# Altium schematic sheet jlink_ob.SchDoc
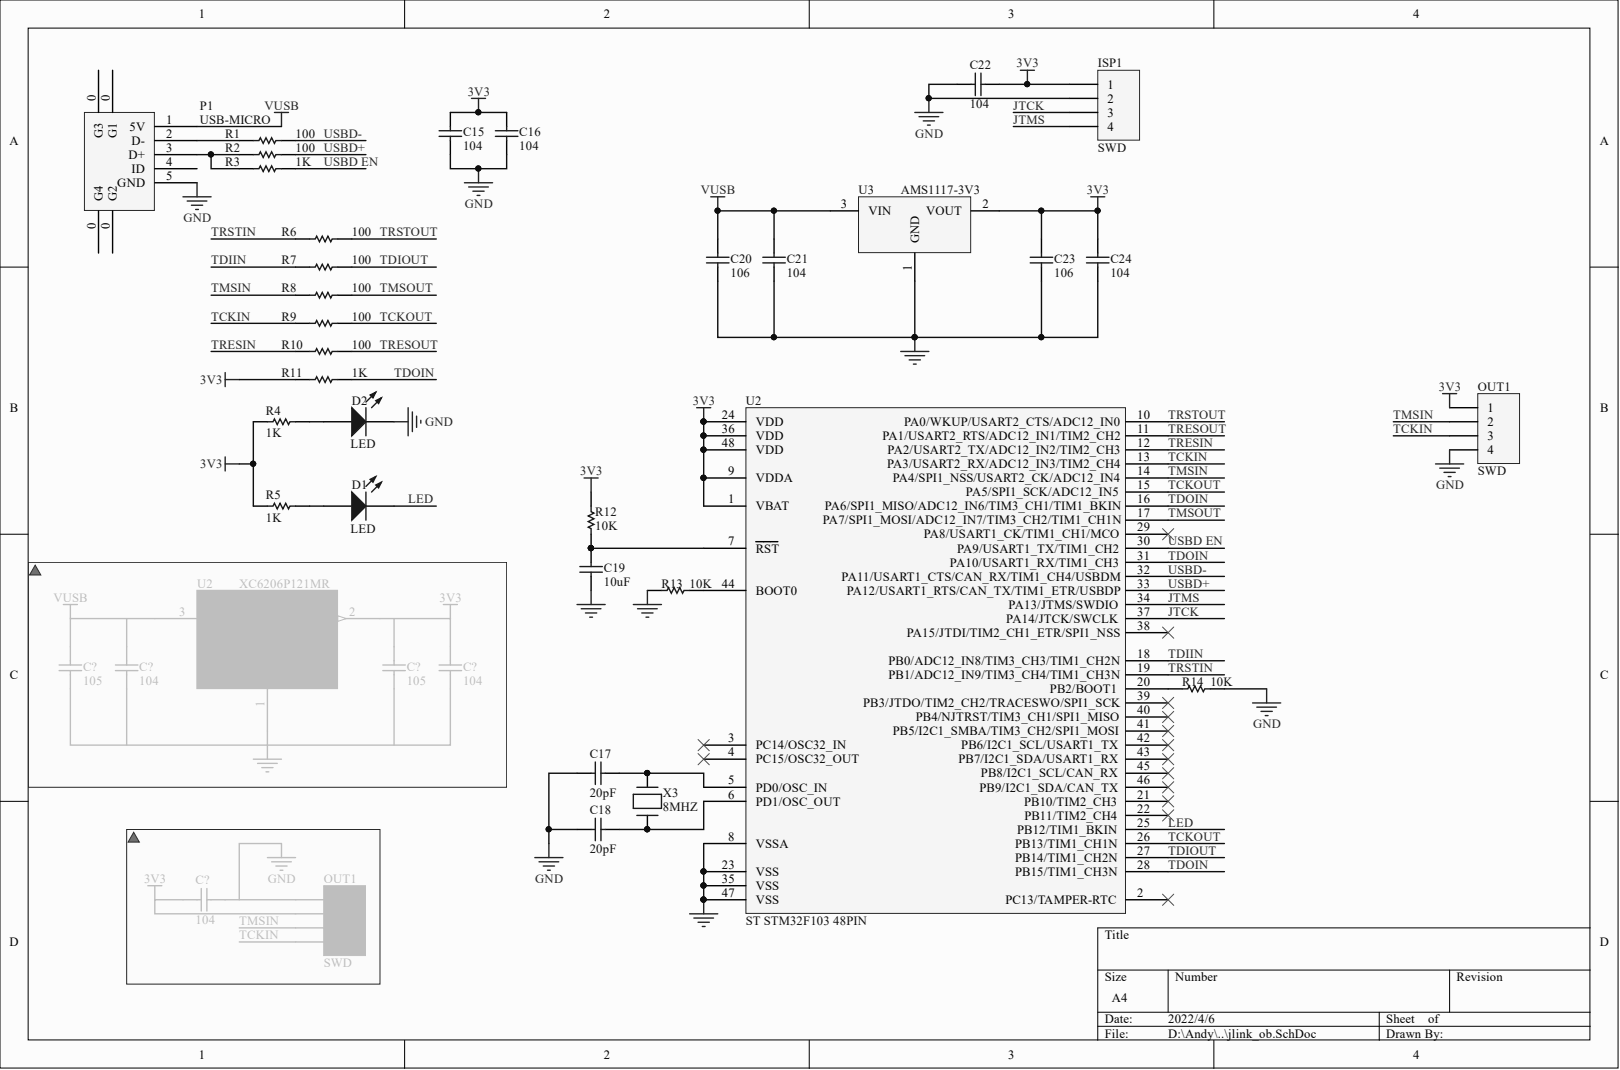
[diagram p0: jlink_ob.SchDoc]

## Parts
C15  value 104  [C0603]  (hidden comment: Cap Semi)
C16  value 104  [C0603]  (hidden comment: Cap Semi)
C17  value 20pF  [C0603]  (hidden comment: Cap Semi)
C18  value 20pF  [C0603]  (hidden comment: Cap Semi)
C19  value 10uF  [C0603]  (hidden comment: Cap Semi)
C20  value 106  [C0603]  (hidden comment: Cap Semi)
C21  value 104  [C0603]  (hidden comment: Cap Semi)
C22  value 104  [C0603]  (hidden comment: Cap Semi)
C23  value 106  [C0603]  (hidden comment: Cap Semi)
C24  value 104  [C0603]  (hidden comment: Cap Semi)
D1  LED  [0603]
D2  LED  [0603]
ISP1  SWD  [HDR1X4]
OUT1  SWD  [HDR1X4]
P1  USB-MICRO  [USB-MICRO]
R1  value 100  [R0603-M]  (hidden comment: Resistors SMD)
R2  value 100  [R0603-M]  (hidden comment: Resistors SMD)
R3  value 1K  [R0603-M]  (hidden comment: Resistors SMD)
R4  value 1K  [R0603-M]  (hidden comment: Resistors SMD)
R5  value 1K  [R0603-M]  (hidden comment: Resistors SMD)
R6  value 100  [R0603-M]  (hidden comment: Resistors SMD)
R7  value 100  [R0603-M]  (hidden comment: Resistors SMD)
R8  value 100  [R0603-M]  (hidden comment: Resistors SMD)
R9  value 100  [R0603-M]  (hidden comment: Resistors SMD)
R10  value 100  [R0603-M]  (hidden comment: Resistors SMD)
R11  value 1K  [R0603-M]  (hidden comment: Resistors SMD)
R12  value 10K  [R0603-M]  (hidden comment: Resistors SMD)
R13  value 10K  [R0603-M]  (hidden comment: Resistors SMD)
R14  value 10K  [R0603-M]  (hidden comment: Resistors SMD)
U2  ST STM32F103 48PIN  [ST LQFP-48 7x7MM]
U3  AMS1117-3V3  [SOT-223]
X3  8MHZ  [XTAL_ABLS2_ABR]

## Nets
3V3: C15.2 C16.2 C22.1 C23.2 C24.1 ISP1.1 OUT1.1 R4.2 R5.2 R11.2 R12.2 U2.1(VBAT) U2.9(VDDA) U2.24(VDD) U2.36(VDD) U2.48(VDD) U3.2
GND: C15.1 C16.1 C17.2 C18.2 C19.1 C20.1 C21.2 C22.2 C23.1 C24.2 D2.2 ISP1.2 OUT1.4 P1.5(GND) R13.1 R14.2 U2.8(VSSA) U2.23(VSS) U2.35(VSS) U2.47(VSS) U3.1
VUSB: C20.2 C21.1 P1.1(5V) U3.3
JTCK: ISP1.3 U2.37(PA14/JTCK/SWCLK)
JTMS: ISP1.4 U2.34(PA13/JTMS/SWDIO)
LED: D1.2 U2.25(PB12/TIM1_BKIN)
TCKIN: OUT1.3 R9.1 U2.13(PA3/USART2_RX/ADC12_IN3/TIM2_CH4)
TCKOUT: R9.2 U2.15(PA5/SPI1_SCK/ADC12_IN5) U2.26(PB13/TIM1_CH1N)
TDIIN: R7.1 U2.18(PB0/ADC12_IN8/TIM3_CH3/TIM1_CH2N)
TDIOUT: R7.2 U2.27(PB14/TIM1_CH2N)
TDOIN: R11.1 U2.16(PA6/SPI1_MISO/ADC12_IN6/TIM3_CH1/TIM1_BKIN) U2.28(PB15/TIM1_CH3N) U2.31(PA10/USART1_RX/TIM1_CH3)
TMSIN: OUT1.2 R8.1 U2.14(PA4/SPI1_NSS/USART2_CK/ADC12_IN4)
TMSOUT: R8.2 U2.17(PA7/SPI1_MOSI/ADC12_IN7/TIM3_CH2/TIM1_CH1N)
TRESIN: R10.1 U2.12(PA2/USART2_TX/ADC12_IN2/TIM2_CH3)
TRESOUT: R10.2 U2.11(PA1/USART2_RTS/ADC12_IN1/TIM2_CH2)
TRSTIN: R6.1 U2.19(PB1/ADC12_IN9/TIM3_CH4/TIM1_CH3N)
TRSTOUT: R6.2 U2.10(PA0/WKUP/USART2_CTS/ADC12_IN0)
USBD EN: R3.2 U2.30(PA9/USART1_TX/TIM1_CH2)
USBD+: R2.2 U2.33(PA12/USART1_RTS/CAN_TX/TIM1_ETR/USBDP)
USBD-: R1.2 U2.32(PA11/USART1_CTS/CAN_RX/TIM1_CH4/USBDM)
NetC17_1: C17.1 U2.5(PD0/OSC_IN) X3.2
NetC18_1: C18.1 U2.6(PD1/OSC_OUT) X3.1
NetC19_2: C19.2 R12.1 U2.7(RST)
NetD1_1: D1.1 R5.1
NetD2_1: D2.1 R4.1
NetP1_2: P1.2(D-) R1.1
NetP1_3: P1.3(D+) R2.1 R3.1
NetR13_2: R13.2 U2.44(BOOT0)
NetR14_1: R14.1 U2.20(PB2/BOOT1)

Not connected: P1 0(G1) 4(ID); U2 2(PC13/TAMPER-RTC) 3(PC14/OSC32_IN) 4(PC15/OSC32_OUT) 21(PB10/TIM2_CH3) 22(PB11/TIM2_CH4) 29(PA8/USART1_CK/TIM1_CH1/MCO) 38(PA15/JTDI/TIM2_CH1_ETR/SPI1_NSS) 39(PB3/JTDO/TIM2_CH2/TRACESWO/SPI1_SCK) 40(PB4/NJTRST/TIM3_CH1/SPI1_MISO) 41(PB5/I2C1_SMBA/TIM3_CH2/SPI1_MOSI) 42(PB6/I2C1_SCL/USART1_TX) 43(PB7/I2C1_SDA/USART1_RX) 45(PB8/I2C1_SCL/CAN_RX) 46(PB9/I2C1_SDA/CAN_TX)

## Library data of the parts
C15-C24 = Cap Semi: Capacitor (Semiconductor SIM Model); Component Kind: Standard; LatestRevisionDate: 29-May-2009; LatestRevisionNote: IPC-7351 Footprint Added.; Library Name: Mylibrary.IntLib; PackageDescription: Chip Capacitor; PackageReference: C1206; Published: 8-Jun-2000; Signal Integrity: Cap Semi; Simulation: CAP; Publisher: Altium Limited
D1 D2 = LED: Typical INFRARED GaAs LED; Component Kind: Standard; LatestRevisionDate: 08-Jul-2005; LatestRevisionNote: Mech Layer 1 removed.; Library Name: Mylibrary.IntLib; PackageDescription: LED; 2 Leads; PackageReference: LED-0; Published: 8-Jun-2000; Simulation: LED0; Publisher: Altium Limited
ISP1 OUT1 = Header 4: Header, 4-Pin; Component Kind: Standard; LatestRevisionDate: 17-Jul-2002; LatestRevisionNote: Re-released for DXP Platform.; Library Name: Miscellaneous Connectors.IntLib; Signal Integrity: Connector; Publisher: Altium Limited
R1-R14 = Resistors SMD: Resistor; Component Kind: Standard; Library Name: Mylibrary.IntLib; Signal Integrity: Res; Simulation: RESISTOR
U3 = AMS1117: Component Kind: Standard; Library Name: Mylibrary.IntLib
X3 = crystal: No Description Available; Manufacturer_Part_Number: ABLS-8.000MHZ-B4-T; Built_By: EMA_Matt; SourceLibrary: Abracon_10-11-2019; Vendor: Abracon; Component Kind: Standard; Library Name: Mylibrary.IntLib; RefDes: RefDes; Type: Type; Copyright: Copyright (C) 2018 Accelerated Designs. All rights reserved
P1 = USB-MICRO: Component Kind: Standard; Description: USB-MICRO; Library Name: Mylibrary.IntLib
U2 = ST STM32F103 48PIN: Component Kind: Standard; Library Name: Mylibrary.IntLib
